# Altium schematic sheet GNSS_BLE.SchDoc
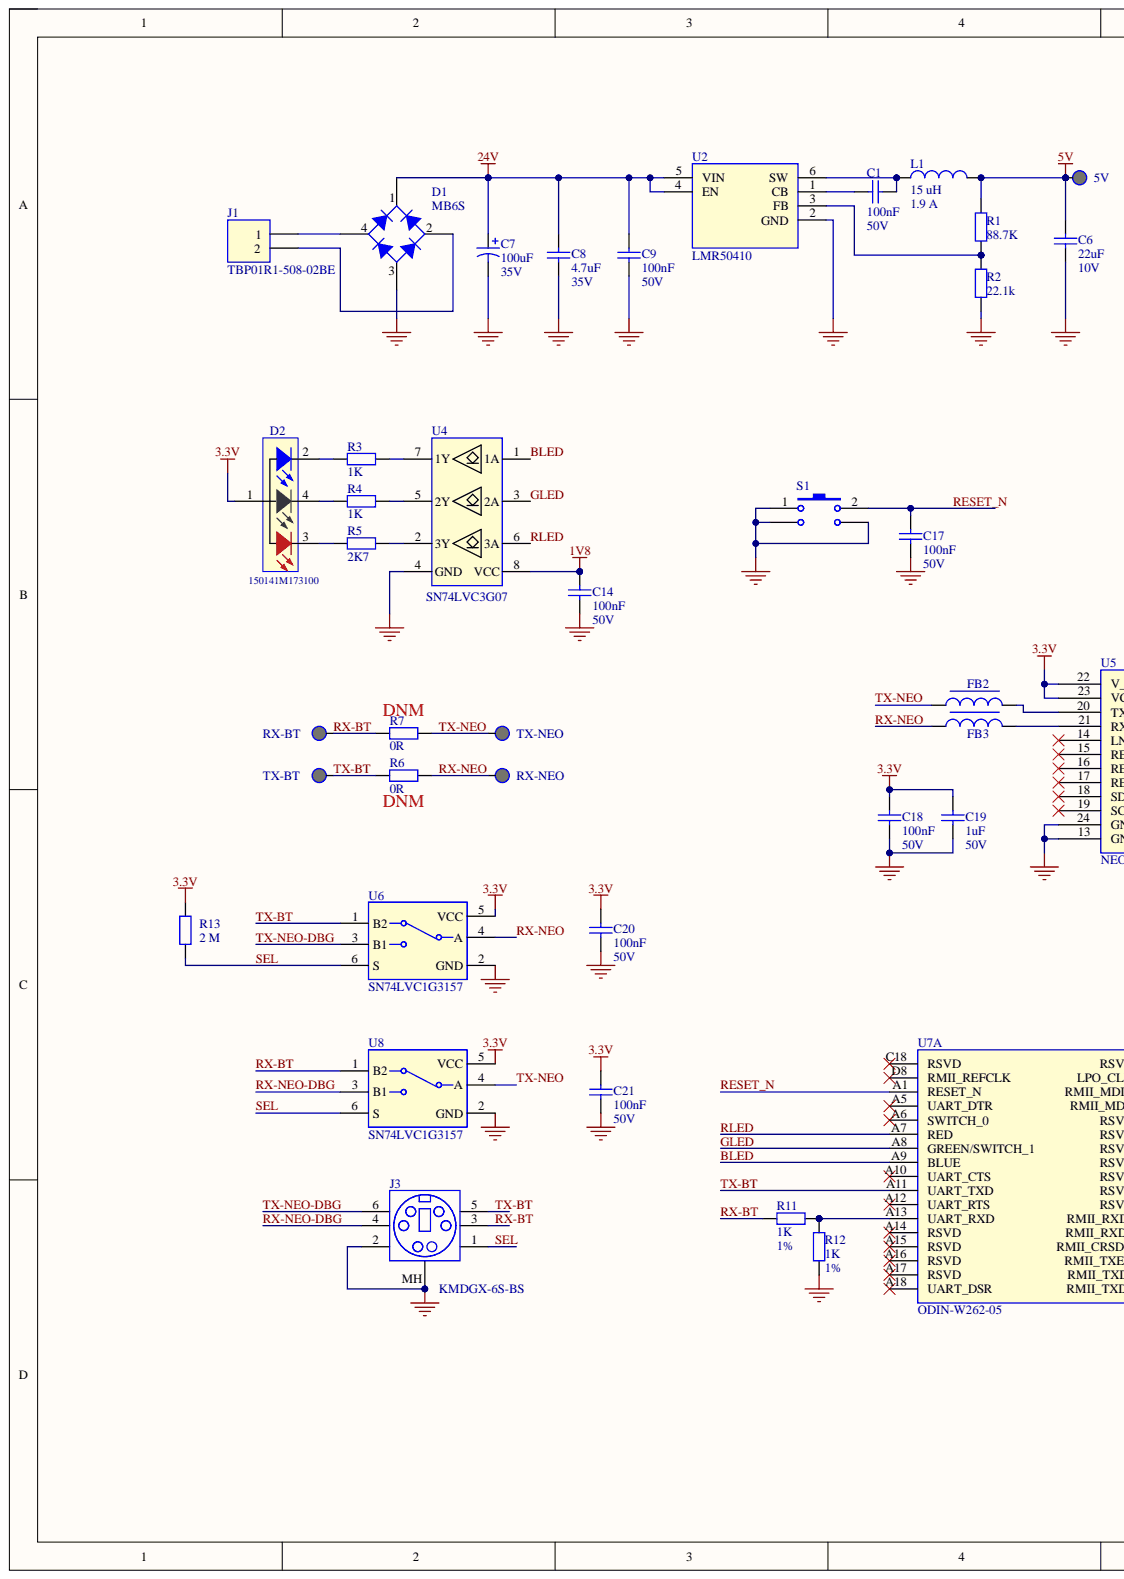
[diagram p0: GNSS_BLE.SchDoc, left]
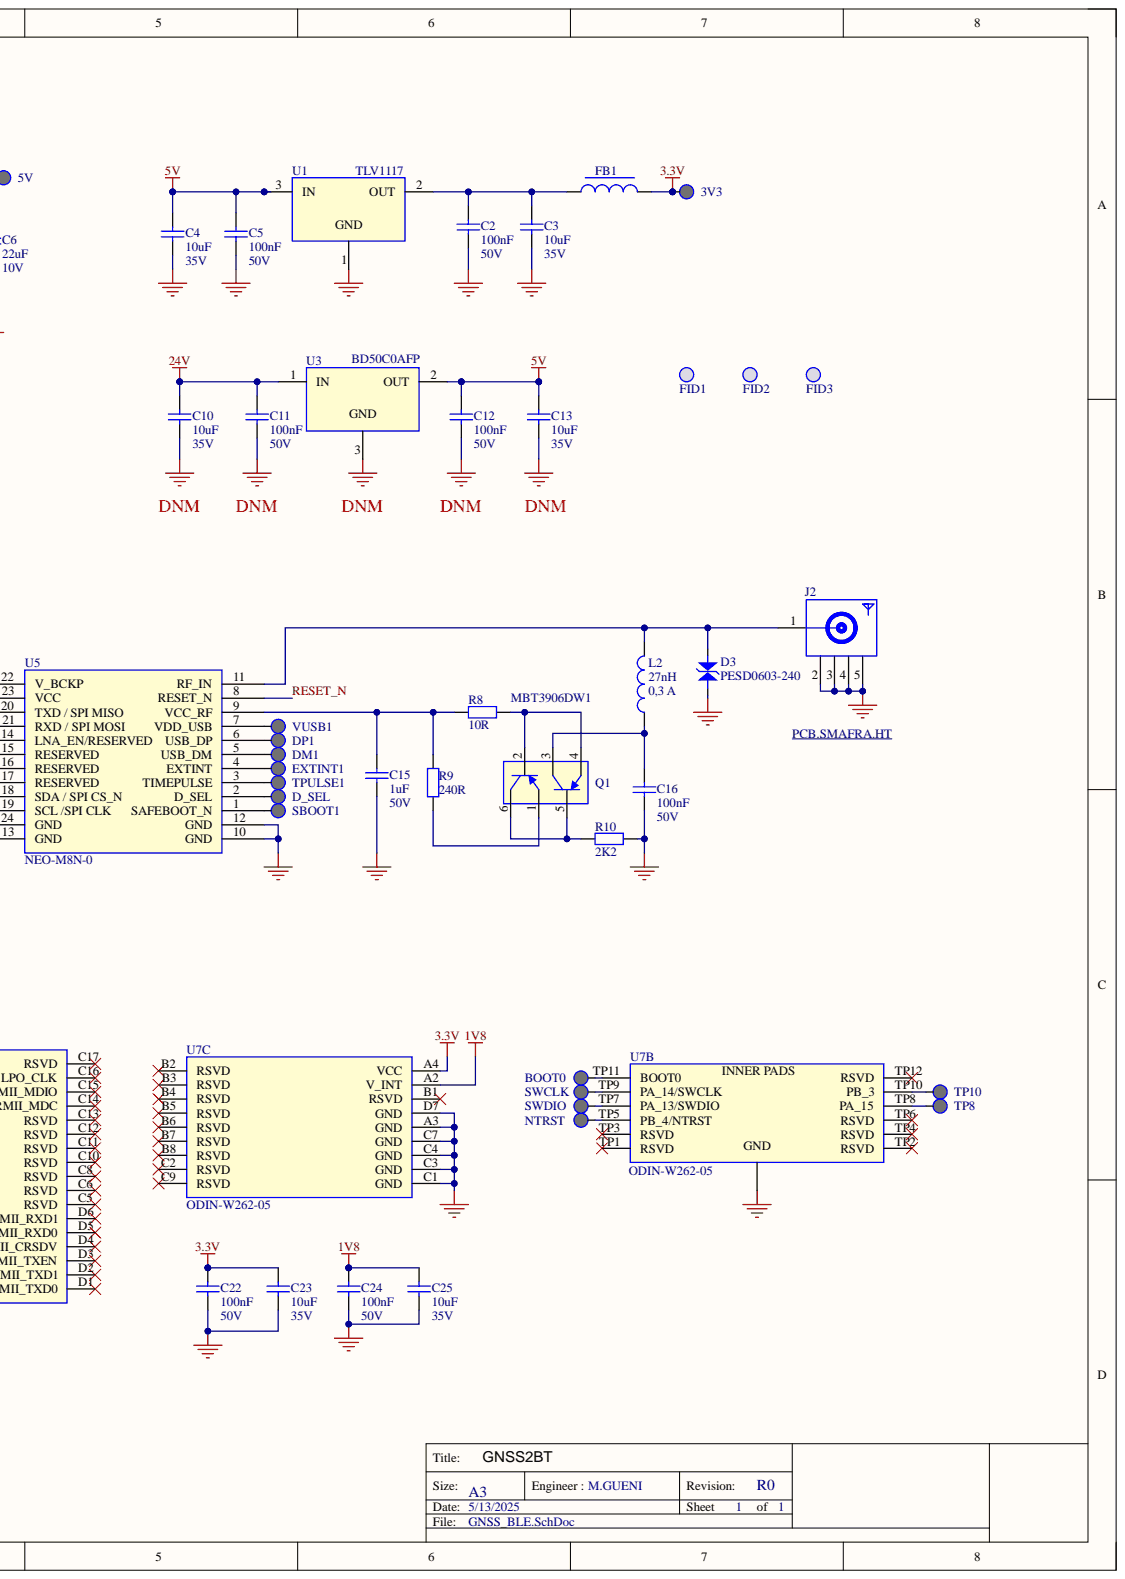
[diagram p1: GNSS_BLE.SchDoc, right]

## Parts
3V3  Test Point  [TP]  (value not drawn)  (no BOM)
5V  Test Point  [TP]  (value not drawn)  (no BOM)
BOOT0  Test Point  [TP]  (value not drawn)  (no BOM)
C1  Value 1: 100nF  Value 2: 50V  [CAPC1608N]  (hidden comment: C0603C104K5RAC3121)
C2  Value 1: 100nF  Value 2: 50V  [CAPC1608N]  (hidden comment: C0603C104K5RAC3121)
C3  Value 1: 10uF  Value 2: 35V  [CAPC1608N]  (hidden comment: GRM188R6YA106MA73D)
C4  Value 1: 10uF  Value 2: 35V  [CAPC1608N]  (hidden comment: GRM188R6YA106MA73D)
C5  Value 1: 100nF  Value 2: 50V  [CAPC1608N]  (hidden comment: C0603C104K5RAC3121)
C6  Value 1: 22uF  Value 2: 10V  [CAPC1608N]  (hidden comment: GRM188R61A226ME15D)
C7  Value 1: 100uF  Value 2: 35V  [ECAP_SMD_6.3]  (hidden comment: EEEFK1V101XP)
C8  Value 1: 4.7uF  Value 2: 35V  [CAPC1608N]  (hidden comment: GRM188R6YA475KE5D)
C9  Value 1: 100nF  Value 2: 50V  [CAPC1608N]  (hidden comment: C0603C104K5RAC3121)
C10  Value 1: 10uF  Value 2: 35V  [CAPC1608N]  (hidden comment: GRM188R6YA106MA73D)
C11  Value 1: 100nF  Value 2: 50V  [CAPC1608N]  (hidden comment: C0603C104K5RAC3121)
C12  Value 1: 100nF  Value 2: 50V  [CAPC1608N]  (hidden comment: C0603C104K5RAC3121)
C13  Value 1: 10uF  Value 2: 35V  [CAPC1608N]  (hidden comment: GRM188R6YA106MA73D)
C14  Value 1: 100nF  Value 2: 50V  [CAPC1608N]  (hidden comment: C0603C104K5RAC3121)
C15  Value 1: 1uF  Value 2: 50V  [CAPC1608N]  (hidden comment: GRT188R61H105KE13D)
C16  Value 1: 100nF  Value 2: 50V  [CAPC1608N]  (hidden comment: C0603C104K5RAC3121)
C17  Value 1: 100nF  Value 2: 50V  [CAPC1608N]  (hidden comment: C0603C104K5RAC3121)
C18  Value 1: 100nF  Value 2: 50V  [CAPC1608N]  (hidden comment: C0603C104K5RAC3121)
C19  Value 1: 1uF  Value 2: 50V  [CAPC1608N]  (hidden comment: GRT188R61H105KE13D)
C20  Value 1: 100nF  Value 2: 50V  [CAPC1608N]  (hidden comment: C0603C104K5RAC3121)
C21  Value 1: 100nF  Value 2: 50V  [CAPC1608N]  (hidden comment: C0603C104K5RAC3121)
C22  Value 1: 100nF  Value 2: 50V  [CAPC1608N]  (hidden comment: C0603C104K5RAC3121)
C23  Value 1: 10uF  Value 2: 35V  [CAPC1608N]  (hidden comment: GRM188R6YA106MA73D)
C24  Value 1: 100nF  Value 2: 50V  [CAPC1608N]  (hidden comment: C0603C104K5RAC3121)
C25  Value 1: 10uF  Value 2: 35V  [CAPC1608N]  (hidden comment: GRM188R6YA106MA73D)
D1  MB6S  [MB6S]
D2  150141M173100  [150141M173100]
D3  PESD0603-240  [TVS1608]
D_SEL  Test Point  [TP]  (value not drawn)  (no BOM)
DM1  Test Point  [TP]  (value not drawn)  (no BOM)
DP1  Test Point  [TP]  (value not drawn)  (no BOM)
EXTINT1  Test Point  [TP]  (value not drawn)  (no BOM)
FB1  2512067007Y3  [FB3216]  (value not drawn)
FB2  BLM21BD102SZ1D  [FB2012]  (value not drawn)
FB3  BLM21BD102SZ1D  [FB2012]  (value not drawn)
FID1  Fiducial  [FIDUCIAL-1MM]  (value not drawn)
FID2  Fiducial  [FIDUCIAL-1MM]  (value not drawn)
FID3  Fiducial  [FIDUCIAL-1MM]  (value not drawn)
J1  TBP01R1-508-02BE  [CUI_TBP01R1-508-02BE]
J2  PCB.SMAFRA.HT  [PCB.SMAFRA.HT]
J3  KMDGX-6S-BS  [KMDGX6SBS]
L1  Value 1: 15 uH  [IND4020]  (hidden comment: 74438356150)
L2  Value 1: 27nH  Value 2: 0,3 A  [IND1608N]  (hidden comment: L0603C27NJRMST)
NTRST  Test Point  [TP]  (value not drawn)  (no BOM)
Q1  MBT3906DW1  [SOT-363-6]
R1  Value 1: 88.7K  [RESC1608N]  (hidden comment: CR0603-FX-8872ELF)
R2  Value 1: 22.1k  [RESC1608N]  (hidden comment: CRCW060322K1FKEA)
R3  Value 1: 1K  [RESC1608N]  (hidden comment: ERJ-3GEYJ102V)
R4  Value 1: 1K  [RESC1608N]  (hidden comment: ERJ-3GEYJ102V)
R5  Value 1: 2K7  [RESC1608N]  (hidden comment: RC0603JR-072K7L)
R6  Value 1: 0R  [RESC1608N]  (hidden comment: MCT06030Z0000ZP500)
R7  Value 1: 0R  [RESC1608N]  (hidden comment: MCT06030Z0000ZP500)
R8  Value 1: 10R  [RESC1608N]  (hidden comment: CRCW060310R0FKEAC)
R9  Value 1: 240R  [RESC1608N]  (hidden comment: CRCW0603240RFKEA)
R10  Value 1: 2K2  [RESC1608N]  (hidden comment: RC0603JR-072K2L)
R11  TOL: 1%  Value 1: 1K  [RESC1608N]  (hidden comment: ERJ-3GEYJ102V)
R12  TOL: 1%  Value 1: 1K  [RESC1608N]  (hidden comment: ERJ-3GEYJ102V)
R13  Value 1: 2 M  [RESC1608N]  (hidden comment: MCT06030C2004FP500)
RX-BT  Test Point  [TP]  (value not drawn)  (no BOM)
RX-NEO  Test Point  [TP]  (value not drawn)  (no BOM)
S1  1825027-8  [218250270]  (value not drawn)
SBOOT1  Test Point  [TP]  (value not drawn)  (no BOM)
SWCLK  Test Point  [TP]  (value not drawn)  (no BOM)
SWDIO  Test Point  [TP]  (value not drawn)  (no BOM)
TP8  Test Point  [TP]  (value not drawn)  (no BOM)
TP10  Test Point  [TP]  (value not drawn)  (no BOM)
TPULSE1  Test Point  [TP]  (value not drawn)  (no BOM)
TX-BT  Test Point  [TP]  (value not drawn)  (no BOM)
TX-NEO  Test Point  [TP]  (value not drawn)  (no BOM)
U1  TLV1117  [SOT223]
U2  LMR50410  [SOT-23-6]
U3  BD50C0AFP  [TO-252-3]
U4  SN74LVC3G07  [VSSOP-8]
U5  NEO-M8N-0  [NEO-M8N]
U6  SN74LVC1G3157  [SOT-23-6]
U7  ODIN-W262-05  [ODIN-W26]  (parts A,B,C)
U8  SN74LVC1G3157  [SOT-23-6]
VUSB1  Test Point  [TP]  (value not drawn)  (no BOM)

## Nets
1V8: C14.1 C24.2 C25.2 U4.8(VCC) U7.A2(V_INT)
3.3V: 3V3.1 C18.1 C19.1 C20.2 C21.2 C22.2 C23.2 D2.1 FB1.2 R13.2 U5.22(V_BCKP) U5.23(VCC) U6.5(VCC) U7.A4(VCC) U8.5(VCC)
5V: 5V.1 C4.1 C5.1 C6.1 C12.1 C13.2 L1.2 R1.1 U1.3 U3.2
24V: C7.1 C8.1 C9.1 C10.1 C11.2 D1.1 U2.4(EN) U2.5(VIN) U3.1
GND: C2.2 C3.2 C4.2 C5.2 C6.2 C7.2 C8.2 C9.2 C10.2 C11.1 C12.2 C13.1 C14.2 C15.2 C16.1 C17.2 C18.2 C19.2 C20.1 C21.1 C22.1 C23.1 C24.1 C25.1 D1.3 D3.1 J2.2 J2.3 J2.4 J2.5 J3.2 J3.MH R2.2 R10.1 R12.1 S1.1 S1.MH U1.1 U2.2(GND) U3.3 U4.4(GND) U5.10(GND) U5.12(GND) U5.13(GND) U5.24(GND) U6.2(GND) U7.A3(GND) U7.C1(GND) U7.C3(GND) U7.C4(GND) U7.C7(GND) U7.D7(GND) U7.GND U8.2(GND)
BLED: U4.1(1A) U7.A9(BLUE)
GLED: U4.3(2A) U7.A8(GREEN/SWITCH_1)
RESET_N: C17.1 S1.2 U5.8(RESET_N) U7.A1(RESET_N)
RLED: U4.6(3A) U7.A7(RED)
RX-BT: J3.3 R7.2 R11.1 RX-BT.1 U8.1(B2)
RX-NEO: FB3.1 R6.1 RX-NEO.1 U6.4(A)
RX-NEO-DBG: J3.4 U8.3(B1)
SEL: J3.1 R13.1 U6.6(S) U8.6(S)
TX-BT: J3.5 R6.2 TX-BT.1 U6.1(B2) U7.A11(UART_TXD)
TX-NEO: FB2.1 R7.1 TX-NEO.1 U8.4(A)
TX-NEO-DBG: J3.6 U6.3(B1)
NetBOOT0_1: BOOT0.1 U7.TP11(BOOT0)
NetC1_1: C1.1 U2.1(CB)
NetC1_2: C1.2 L1.1 U2.6(SW)
NetC2_1: C2.1 C3.1 FB1.1 U1.2
NetC15_1: C15.1 R8.1 R9.1 U5.9(VCC_RF)
NetC16_2: C16.2 L2.1 Q1.3
NetD1_2: D1.2 J1.2
NetD1_4: D1.4 J1.1
NetD2_2: D2.2 R3.2
NetD2_3: D2.3 R5.2
NetD2_4: D2.4 R4.2
NetD3_2: D3.2 J2.1 L2.2 U5.11(RF_IN)
NetD_SEL_1: D_SEL.1 U5.2(D_SEL)
NetDM1_1: DM1.1 U5.5(USB_DM)
NetDP1_1: DP1.1 U5.6(USB_DP)
NetEXTINT1_1: EXTINT1.1 U5.4(EXTINT)
NetFB2_2: FB2.2 U5.20(TXD_/_SPI_MISO)
NetFB3_2: FB3.2 U5.21(RXD_/_SPI_MOSI)
NetNTRST_1: NTRST.1 U7.TP5(PB_4/NTRST)
NetQ1_1: Q1.1 R9.2
NetQ1_2: Q1.2 Q1.4 R8.2
NetQ1_5: Q1.5 Q1.6 R10.2
NetR1_2: R1.2 R2.1 U2.3(FB)
NetR3_1: R3.1 U4.7(1Y)
NetR4_1: R4.1 U4.5(2Y)
NetR5_1: R5.1 U4.2(3Y)
NetR11_2: R11.2 R12.2 U7.A13(UART_RXD)
NetSBOOT1_1: SBOOT1.1 U5.1(SAFEBOOT_N)
NetSWCLK_1: SWCLK.1 U7.TP9(PA_14/SWCLK)
NetSWDIO_1: SWDIO.1 U7.TP7(PA_13/SWDIO)
NetTP8_1: TP8.1 U7.TP8(PA_15)
NetTP10_1: TP10.1 U7.TP10(PB_3)
NetTPULSE1_1: TPULSE1.1 U5.3(TIMEPULSE)
NetU5_7: U5.7(VDD_USB) VUSB1.1

Not connected: U5 14(LNA_EN/RESERVED) 15(RESERVED) 16(RESERVED) 17(RESERVED) 18(SDA_/_SPI_CS_N) 19(SCL_/SPI_CLK); U7 A5(UART_DTR) A6(SWITCH_0) A10(UART_CTS) A12(UART_RTS) A14(RSVD) A15(RSVD) A16(RSVD) A17(RSVD) A18(UART_DSR) B1(RSVD) B2(RSVD) B3(RSVD) B4(RSVD) B5(RSVD) B6(RSVD) B7(RSVD) B8(RSVD) C2(RSVD) C5(RSVD) C6(RSVD) C8(RSVD) C9(RSVD) C10(RSVD) C11(RSVD) C12(RSVD) C13(RSVD) C14(RMII_MDC) C15(RMII_MDIO) C16(LPO_CLK) C17(RSVD) C18(RSVD) D1(RMII_TXD0) D2(RMII_TXD1) D3(RMII_TXEN) D4(RMII_CRSDV) D5(RMII_RXD0) D6(RMII_RXD1) D8(RMII_REFCLK) TP1(RSVD) TP2(RSVD) TP3(RSVD) TP4(RSVD) TP6(RSVD) TP12(RSVD)

## Library data of the parts
3V3 5V BOOT0 D_SEL DM1 DP1 EXTINT1 NTRST RX-BT RX-NEO SBOOT1 SWCLK SWDIO TP8 TP10 TPULSE1 TX-BT TX-NEO VUSB1 = MIS-000000001: Database Table Name: Miscellaneous; DES: _; FTP: _; Library Name: GNSS.DbLib; MAN: _; MANREF: _; NOTE: _; Part Number: MIS-000000001; SUP: _; SUPREF: _; TOL: _; Value 1: _; Value 2: _
C1 C2 C5 C9 C11 C12 C14 C16-C18 C20-C22 C24 = CAP-000000001: Database Table Name: Ceramic Capacitor; DES: Condensateurs céramique multicouches MLCC - CMS 50V .1uF X7R 0603 10% AEC-Q200; FTP: 0603; MAN: KEMET; NOTE: _; SUP: Mouser; SUPREF: 80-C603C104K5RAC3121; TOL: 10%; Component Kind: Standard; Library Name: GNSS.DbLib; MANREF: C0603C104K5RAC3121; Part Number: CAP-000000001
C3 C4 C10 C13 C23 C25 = CAP-000000003: Database Table Name: Ceramic Capacitor; DES: Multilayer Ceramic Capacitors MLCC - SMD/SMT 0603 10uF 35volts *Derate Voltage/Temp; FTP: 0603; MAN: Murata Electronics; NOTE: _; SUP: Mouser; SUPREF: 81-GRM188R6YA106MA3D; TOL: 20%; Component Kind: Standard; Library Name: GNSS.DbLib; MANREF: GRM188R6YA106MA73D; Part Number: CAP-000000003
C6 = CAP-000000006: Database Table Name: Ceramic Capacitor; DES: Multilayer Ceramic Capacitors MLCC - SMD/SMT 0603 22uF 10volts *Derate Voltage/Temp; FTP: 0603; MAN: Murata Electronics; NOTE: _; SUP: Mouser; SUPREF: 81-GRM188R61A226ME5D; TOL: 20%; Component Kind: Standard; Library Name: GNSS.DbLib; MANREF: GRM188R61A226ME15D; Part Number: CAP-000000006
C7 = CAP-000000001: Database Table Name: Electrolytic Capacitors; DES: PANASONIC ELECTRONIC COMPONENTS  EEEFK1V101XP  CONDENSATEUR ELEC ALU 100UF 35V CMS; FTP: SMD Size D8; MAN: PANASONIC; NOTE: _; SUP: Mouser; SUPREF: 667-EEE-FK1V101XP    V101XP; TOL: 20%; Component Kind: Standard; Library Name: GNSS.DbLib; MANREF: EEEFK1V101XP; Part Number: CAP-000000001
C8 = CAP-000000005: Database Table Name: Ceramic Capacitor; DES: Multilayer Ceramic Capacitors MLCC - SMD/SMT 4.7UF 16V 10% 0603; FTP: 0603; MAN: Murata Electronics; NOTE: _; SUP: Mouser; SUPREF: 81-GRM188R6YA475KE5D; TOL: 10%; Component Kind: Standard; Library Name: GNSS.DbLib; MANREF: GRM188R6YA475KE5D; Part Number: CAP-000000005
C15 C19 = CAP-000000004: Database Table Name: Ceramic Capacitor; DES: Condensateurs céramique multicouches MLCC - CMS 0603 50Vdc 1.0uF X5R 10%; FTP: 0603; MAN: Murata Electronics; NOTE: _; SUP: Mouser; SUPREF: 81-GRT188R61H105KE3D; TOL: 10%; Component Kind: Standard; Library Name: GNSS.DbLib; MANREF: GRT188R61H105KE13D; Part Number: CAP-000000004
D1 = DIB-000000001: Database Table Name: Diodes; DES: Redresseurs en pont .5A 600V; FTP: MBS-1; MAN: Micro Commercial Components (MCC); MANREF: MB6S-TP; NOTE: _; SUP: Mouser; SUPREF: 833-MB6S-TP; Value 1: 0.5A; Value 2: 600V; Component Kind: Standard; Library Name: GNSS.DbLib; Part Number: DIB-000000001; TOL: _
D2 = LED-000000001: Database Table Name: Optoelctronic; DES: WL-SFTW SMD Full-color TOP LED Waterclear, size 5050, Red & Green & Blue, 120deg; FTP: 1411 (3528 metric); MAN: Wurth Elektronik; NOTE: _; SUP: Mouser; SUPREF: 710-150141M173100; Value 1: 20 mA; Value 2: 2 V, 3.2 V, 3.2 V; Component Kind: Standard; Library Name: GNSS.DbLib; MANREF: 150141M173100; Part Number: LED-000000001; TOL: _
D3 = TVS-000000001: Database Table Name: ESD Suppressors / TVS Diodes; DES: ESD Suppressors / TVS Diodes 0.25pFA 24V 0603 AEC-Q200; FTP: 0603; MAN: Littelfuse; NOTE: _; SUP: Mouser; SUPREF: 650-PESD0603-240; Value 1: 24 V; Value 2: 0.25pF; Component Kind: Standard; Library Name: GNSS.DbLib; MANREF: PESD0603-240; Part Number: TVS-000000001; TOL: _
FB1 = FER-000000001: Database Table Name: Ferrite; DES: 2512067007Y3 -  Perle de ferrite, 1206 [3216 Metric], 70 ohm, 3 A, 0.04 ohm, ± 25%; FTP: 1206; MAN: FAIR-RITE; NOTE: _; SUP: Mouser; SUPREF: 623-2512067007Y3; TOL: 25%; Value 1: 70Ohm; Value 2: 0R04; Component Kind: Standard; Library Name: GNSS.DbLib; MANREF: 2512067007Y3; Part Number: FER-000000001
FB2 FB3 = FER-000000002: Database Table Name: Ferrite; DES: Ferrite Beads 0805 1000ohms 250mA Auto Infotainment; FTP: 0805; MAN: Murata Electronics; NOTE: _; SUP: Mouser; SUPREF: 81-BLM21BD102SZ1D; TOL: 25%; Value 1: 1K; Value 2: 250mA; Component Kind: Standard; Library Name: GNSS.DbLib; MANREF: BLM21BD102SZ1D; Part Number: FER-000000002
FID1-FID3 = MIS-000000002: Database Table Name: Miscellaneous; DES: _; Value 1: 1mm; Component Kind: Standard; FTP: _; Library Name: GNSS.DbLib; MAN: _; MANREF: _; NOTE: _; Part Number: MIS-000000002; SUP: _; SUPREF: _; TOL: _; Value 2: _
J1 = CON-000000001: Database Table Name: Connectors; DES: Pluggable Terminal Blocks Terminal block, pluggable, 5.08, receptical, 2 pole, blue; FTP: THT; MAN: CUI Devices; MANREF: TBP01R1-508-02BE        39910-0102; NOTE: _; SUP: Mouser; SUPREF: 490-TBP01R1-508-02BE; Value 1: 2pts; Value 2: 5.08 mm; Component Kind: Standard; Library Name: GNSS.DbLib; Part Number: CON-000000001; TOL: _
J2 = CON-000000003: Database Table Name: Connectors; DES: Connecteurs RF / Connecteurs coaxiaux SMA RA PCB Mount, Jack, Gold, 50 , Through Hole Pin; FTP: THT; MAN: Taoglas; NOTE: _; SUP: Mouser; SUPREF: 960-PCB.SMAFRA.HT; Value 1: 5pts; Component Kind: Standard; Library Name: GNSS.DbLib; MANREF: PCB.SMAFRA.HT; Part Number: CON-000000003; TOL: _; Value 2: _
J3 = CON-000000002: Database Table Name: Connectors; DES: Circular DIN Connectors 6P R/A FULL SHIELD GOLD PLANTED; FTP: THT; MAN: Kycon; NOTE: _; SUP: Mouser; SUPREF: 806-KMDGX-6S-BS; Value 1: 6pts; Component Kind: Standard; Library Name: GNSS.DbLib; MANREF: KMDGX-6S-BS; Part Number: CON-000000002; TOL: _; Value 2: _
L1 = IND-000000001: Database Table Name: Inductors; DES: Fixed Inductors WE-MAPI 15uH 1.9A DCR=230mOhms AECQ200; FTP: 4020; MAN: Wurth Elektronik; NOTE: _; SUP: Mouser; SUPREF: 710-74438356150; TOL: 20%; Value 2: 1.9 A; Component Kind: Standard; Library Name: GNSS.DbLib; MANREF: 74438356150; Part Number: IND-000000001
L2 = IND-000000002: Database Table Name: Inductors; DES: Fixed Inductors 27nH 300mA 5%; FTP: 0603; MAN: KEMET; NOTE: _; SUP: Mouser; SUPREF: 80-L0603C27NJRMST; TOL: 5 %; Component Kind: Standard; Library Name: GNSS.DbLib; MANREF: L0603C27NJRMST; Part Number: IND-000000002
Q1 = TRP-000000001: Database Table Name: Transistors; DES: Bipolar Transistors - BJT SS GP XSTR PNP 40V; MAN: ON Semiconductor; MANREF: MBT3906DW1T1G; NOTE: _; SUP: Mouser; SUPREF: 863-MBT3906DW1T1G; Value 1: - 40 V; Value 2: 0.2 A; Component Kind: Standard; FTP: SOT-363-6; Library Name: GNSS.DbLib; Part Number: TRP-000000001; TOL: _
R1 = RES-000000007: Database Table Name: Resistors; DES: Résistances à couches épaisses - CMS 88.7K ohm 1%; FTP: 0603; MAN: Bourns; NOTE: _; SUP: Mouser; SUPREF: 652-CR0603FX-8872ELF; TOL: 1%; Value 2: 0.1W; Component Kind: Standard; Library Name: GNSS.DbLib; MANREF: CR0603-FX-8872ELF; Part Number: RES-000000007
R2 = RES-000000006: Database Table Name: Resistors; DES: Résistances à couches épaisses - CMS 1/10watt 22.1Kohms 1%; FTP: 0603; MAN: Vishay / Dale; NOTE: _; SUP: Mouser; SUPREF: 71-CRCW0603-22.1K-E3; TOL: 1%; Value 2: 0.1W; Component Kind: Standard; Library Name: GNSS.DbLib; MANREF: CRCW060322K1FKEA; Part Number: RES-000000006
R3 R4 = RES-000000001: Database Table Name: Resistors; DES: Résistances à couches épaisses - CMS 0603 1Kohms 5% AEC-Q200; FTP: 0603; MAN: Panasonic; NOTE: _; SUP: Mouser; SUPREF: 667-ERJ-3GEYJ102V; TOL: 1%; Value 2: 0.1W; Component Kind: Standard; Library Name: GNSS.DbLib; MANREF: ERJ-3GEYJ102V; Part Number: RES-000000001
R5 = RES-000000009: Database Table Name: Resistors; DES: Résistances à couches épaisses - CMS 2.7K OHM 5%; FTP: 0603; MAN: YAGEO; NOTE: _; SUP: Mouser; SUPREF: 603-RC0603JR-072K7L; TOL: 5%; Value 2: 0.1W; Component Kind: Standard; Library Name: GNSS.DbLib; MANREF: RC0603JR-072K7L; Part Number: RES-000000009
R6 R7 = RES-000000004: Database Table Name: Resistors; DES: Thin Film Resistors - SMD Zero ohm Jumper; FTP: 0603; MAN: Vishay / Beyschlag; NOTE: _; SUP: Mouser; SUPREF: 594-MCT06030Z0000ZP5; Value 2: 0.125W; Component Kind: Standard; Library Name: GNSS.DbLib; MANREF: MCT06030Z0000ZP500; Part Number: RES-000000004; TOL: _
R8 = RES-000000002: Database Table Name: Resistors; DES: Résistances à couches épaisses - CMS 1/10Watt 10ohms 1% Commercial Use; FTP: 0603; MAN: Vishay / Dale; NOTE: _; SUP: Mouser; SUPREF: 71-CRCW060310R0FKEAC; TOL: 1%; Value 2: 0.1W; Component Kind: Standard; Library Name: GNSS.DbLib; MANREF: CRCW060310R0FKEAC; Part Number: RES-000000002
R9 = RES-000000005: Database Table Name: Resistors; DES: Résistances à couches épaisses - CMS 1/10watt 240ohms 1%; FTP: 0603; MAN: Vishay / Dale; NOTE: _; SUP: Mouser; SUPREF: 71-CRCW0603-240-E3; TOL: 1%; Value 2: 0.1W; Component Kind: Standard; Library Name: GNSS.DbLib; MANREF: CRCW0603240RFKEA; Part Number: RES-000000005
R10 = RES-000000003: Database Table Name: Resistors; DES: Résistances à couches épaisses - CMS 2.2K OHM 5%; FTP: 0603; MAN: YAGEO; NOTE: _; SUP: Mouser; SUPREF: 603-RC0603JR-072K2L; TOL: 5%; Value 2: 0.1W; Component Kind: Standard; Library Name: GNSS.DbLib; MANREF: RC0603JR-072K2L; Part Number: RES-000000003
R11 R12 = RES-000000001: Database Table Name: Resistors; DES: Résistances à couches épaisses - CMS 0603 1Kohms 5% AEC-Q200; FTP: 0603; MAN: Panasonic; NOTE: _; SUP: Mouser; SUPREF: 667-ERJ-3GEYJ102V; Value 2: 0.1W; Component Kind: Standard; Library Name: GNSS.DbLib; MANREF: ERJ-3GEYJ102V; Part Number: RES-000000001
R13 = RES-000000008: Database Table Name: Resistors; DES: Thin Film Resistors .1W 2Mohms 1% 0603 50ppm; FTP: 0603; MAN: Vishay / Beyschlag; NOTE: _; SUP: Mouser; SUPREF: 594-MCT06030C2004FP5; TOL: 1%; Value 2: 0.125W; Component Kind: Standard; Library Name: GNSS.DbLib; MANREF: MCT06030C2004FP500; Part Number: RES-000000008
S1 = SWC-000000001: Database Table Name: Switch; DES: Tactile Switches R/A TACT 160G PB SWITCH; FTP: THT; MAN: TE Connectivity / P&B; NOTE: _; SUP: Mouser; SUPREF: 506-1825027-8; Component Kind: Standard; Library Name: GNSS.DbLib; MANREF: 1825027-8; Part Number: SWC-000000001; TOL: _; Value 1: _; Value 2: _
U1 = VRG-000000001: Database Table Name: Voltage Regulator; DES: TEXAS INSTRUMENTS  TLV1117LV33DCYR  LDO FIXE 3.3V 1A SOT-223-4; FTP: SOT-223; MAN: Texas Instrument; MANREF: TLV1117LV33DCYR; NOTE: _; SUP: Mouser; SUPREF: 595-TLV1117LV33DCYR; Value 1: 3.3V; Value 2: 1A; Component Kind: Standard; Library Name: GNSS.DbLib; Part Number: VRG-000000001; TOL: _
U2 = ICP-000000001: Database Table Name: Power Management IC; DES: Switching Voltage Regulators Automotive qualified SIMPLE SWITCHER 4-V to 36-V, 1-A synchronous step-down converter 6-SOT-23 -40 to 150; MAN: Texas Instruments; MANREF: LMR50410YQDBVRQ1; NOTE: _; SUP: Mouser; SUPREF: 595-LMR50410YQDBVRQ1; Value 1: 36 V; Value 2: 1 A; Component Kind: Standard; FTP: SOT-23-6; Library Name: GNSS.DbLib; Part Number: ICP-000000001; TOL: _
U3 = VRG-000000003: Database Table Name: Voltage Regulator; DES: LDO Voltage Regulators 4-26.5V TO252-3 15A LDO Regulator; MAN: ROHM Semiconductor; MANREF: BD50C0AFP-CE2; NOTE: _; SUP: Mouser; SUPREF: 755-BD50C0AFP-CE2; Value 1: 5V; Value 2: 1A; Component Kind: Standard; FTP: TO-252-3; Library Name: GNSS.DbLib; Part Number: VRG-000000003; TOL: _
U4 = ICD-000000001: Database Table Name: Drivers IC; DES: Buffers & Line Drivers Triple w/ OD; MAN: TEXAS INSTRUMENTS; MANREF: SN74LVC3G07DCUR; NOTE: _; SUP: Mouser; SUPREF: 595-SN74LVC3G07DCUR; Value 1: 5.5 V; Component Kind: Standard; FTP: VSSOP-8; Library Name: GNSS.DbLib; Part Number: ICD-000000001; TOL: _; Value 2: _
U5 = RFC-000000001: Database Table Name: RF; DES: NEO-M8 RF Receiver BeiDou, Galileo, GLONASS, GNSS, GPS 1.575GHz -167dBm; FTP: _; MAN: U-Blox; SUP: Digi-Key; SUPREF: 672-NEO-M8N-0TR-ND; Component Kind: Standard; Library Name: GNSS.DbLib; MANREF: NEO-M8N-0; NOTE: _; Part Number: RFC-000000001; TOL: _; Value 1: _; Value 2: _
U6 U8 = GIC-000000001: Database Table Name: General Purpose IC; DES: Analogue Switch ICs SPDT Analog Switchc; MAN: Texas Instruments; MANREF: SN74LVC1G3157DBVR; NOTE: _; SUP: Mouser; SUPREF: 595-SN74LVC1G3157DBV; Value 1: 5.5 V; Component Kind: Standard; FTP: SOT-23-6; Library Name: GNSS.DbLib; Part Number: GIC-000000001; TOL: _; Value 2: _
U7 = RFC-000000002: Database Table Name: RF; DES: RX TXRX MODULE WIFI CHIP SMD; FTP: _; MAN: U-Blox; MANREF: ODIN-W262-05B; SUP: Digi-Key; SUPREF: 672-ODIN-W262-05BTR-ND; Component Kind: Standard; Library Name: GNSS.DbLib; NOTE: _; Part Number: RFC-000000002; TOL: _; Value 1: _; Value 2: _
